# Altium schematic sheet BLDC_Motor_Reversal_Rev2.SchDoc
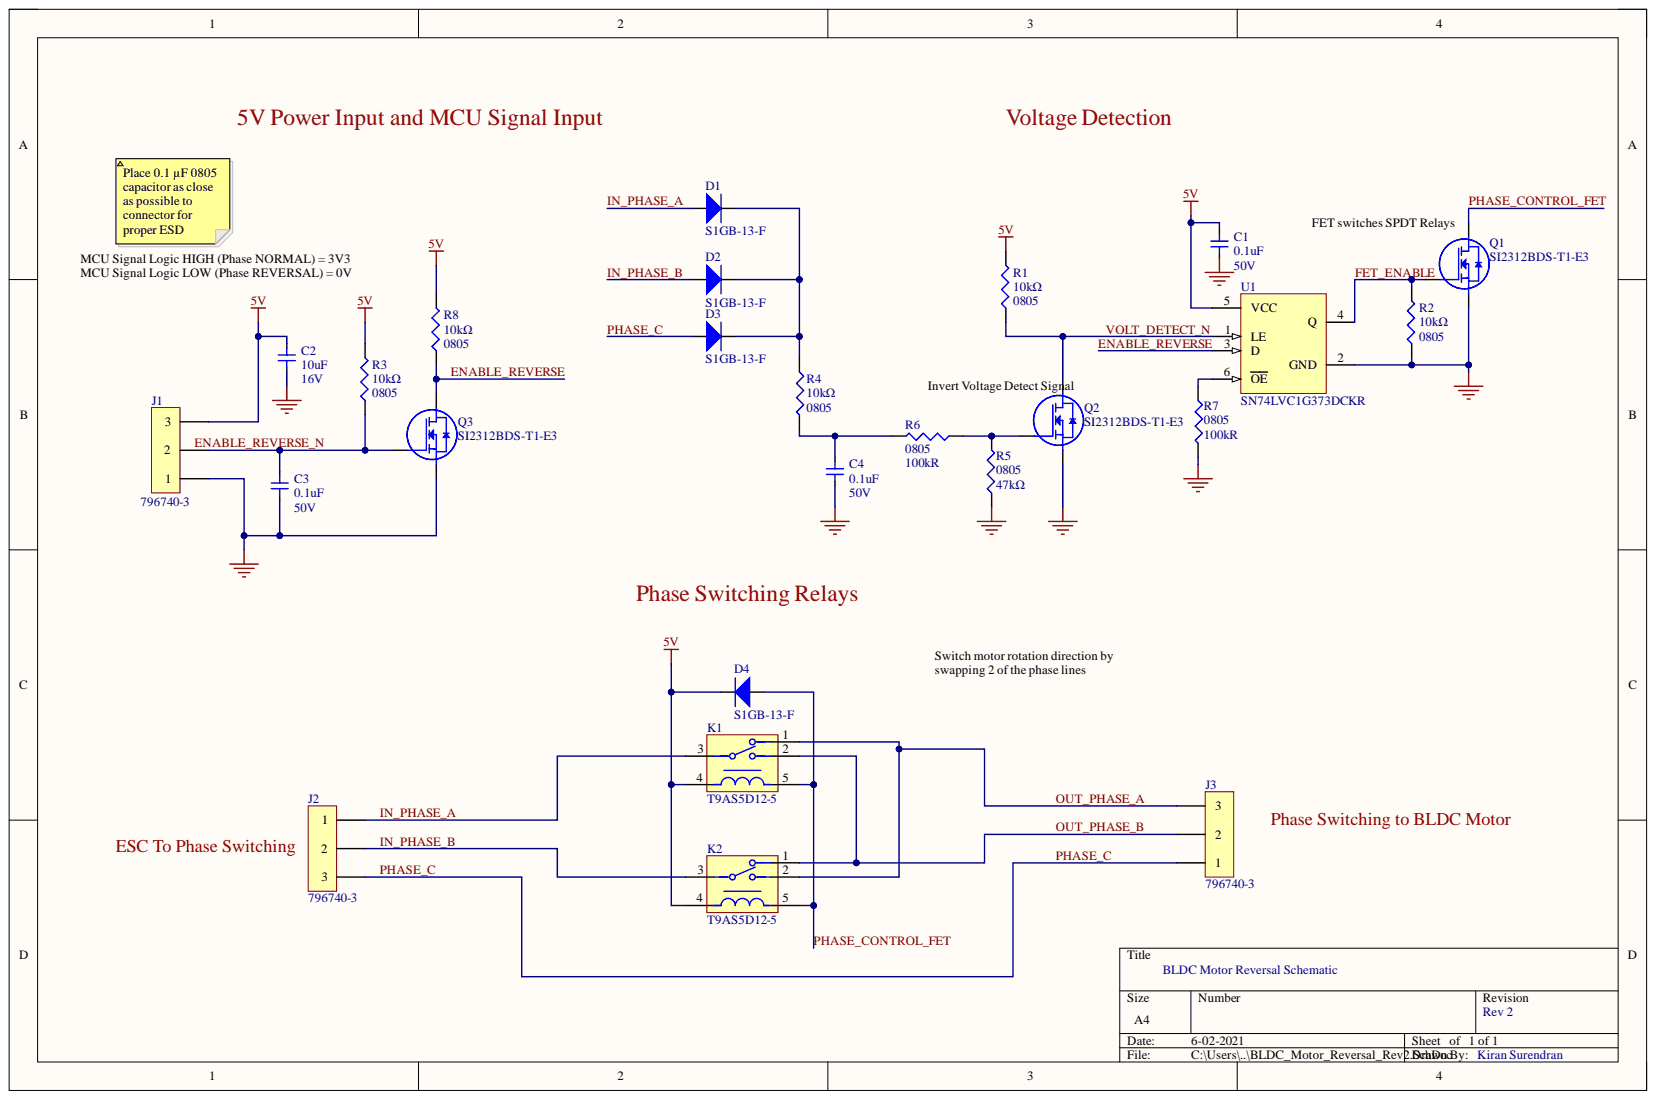
[diagram p0: BLDC_Motor_Reversal_Rev2.SchDoc]

## Parts
C1  Capacitance: 0.1uF  Voltage Rating: 50V  [C0805C104K5RACTU]  (hidden comment: C0805C104K5RACTU)
C2  Capacitance: 10uF  Voltage Rating: 16V  [C0805C106K4PACTU]  (hidden comment: C0805C106K4PACTU)
C3  Capacitance: 0.1uF  Voltage Rating: 50V  [C0805C104K5RACTU]  (hidden comment: C0805C104K5RACTU)
C4  Capacitance: 0.1uF  Voltage Rating: 50V  [C0805C104K5RACTU]  (hidden comment: C0805C104K5RACTU)
D1  S1GB-13-F  [S1GB-13-F]
D2  S1GB-13-F  [S1GB-13-F]
D3  S1GB-13-F  [S1GB-13-F]
D4  S1GB-13-F  [S1GB-13-F]
J1  796740-3  [796740-3]
J2  796740-3  [796740-3]
J3  796740-3  [796740-3]
K1  T9AS5D12-5  [T9AS5D12-5]
K2  T9AS5D12-5  [T9AS5D12-5]
Q1  SI2312BDS-T1-E3  [SI2312BDS-T1-E3]
Q2  SI2312BDS-T1-E3  [SI2312BDS-T1-E3]
Q3  SI2312BDS-T1-E3  [SI2312BDS-T1-E3]
R1  Package: 0805  Resistance: 10kΩ  [RC0805JR-0710KL]  (hidden comment: RC0805JR-0710KL)
R2  Package: 0805  Resistance: 10kΩ  [RC0805JR-0710KL]  (hidden comment: RC0805JR-0710KL)
R3  Package: 0805  Resistance: 10kΩ  [RC0805JR-0710KL]  (hidden comment: RC0805JR-0710KL)
R4  Package: 0805  Resistance: 10kΩ  [RC0805JR-0710KL]  (hidden comment: RC0805JR-0710KL)
R5  Package: 0805  Resistance: 47kΩ  [RC0805JR-0747KL]  (hidden comment: RC0805JR-0747KL)
R6  Case Code (Imperial): 0805  Resistance: 100kR  [RC0805JR-07100KL]  (hidden comment: RC0805JR-07100KL)
R7  Case Code (Imperial): 0805  Resistance: 100kR  [RC0805JR-07100KL]  (hidden comment: RC0805JR-07100KL)
R8  Package: 0805  Resistance: 10kΩ  [RC0805JR-0710KL]  (hidden comment: RC0805JR-0710KL)
U1  SN74LVC1G373DCKR  [DCK0006A_N]

## Nets
5V: C1.2 C2.2 D4.1 J1.3 K1.4 K2.4 R1.2 R3.2 R8.2 U1.5(VCC)
GND: C1.1 C2.1 C3.1 C4.1 J1.1 Q1.2 Q2.2 Q3.2 R2.1 R5.1 R7.2 U1.2(GND)
ENABLE_REVERSE: Q3.3 R8.1 U1.3(D)
FET_ENABLE: Q1.1 R2.2 U1.4(Q)
IN_PHASE_A: D1.1 J2.1 K1.3
IN_PHASE_B: D2.1 J2.2 K2.3
OUT_PHASE_A: J3.3 K1.1 K2.2
OUT_PHASE_B: J3.2 K1.2 K2.1
PHASE_C: D3.1 J2.3 J3.1
PHASE_CONTROL_FET: D4.2 K1.5 K2.5 Q1.3
VOLT_DETECT_N: Q2.3 R1.1 U1.1(LE)
NetC3_2: C3.2 J1.2 Q3.1 R3.1
NetC4_2: C4.2 R4.2 R6.2
NetD1_2: D1.2 D2.2 D3.2 R4.1
NetQ2_1: Q2.1 R5.2 R6.1
NetR7_1: R7.1 U1.6(OE)
ENABLE_REVERSE_N:

## Library data of the parts
C1 C3 C4 = C0805C104K5RACTU: Cap Ceramic 0.1uF 50V X7R 10% SMD 2.0 x 1.2 mm 125 C Paper T/R; Manufacturer 1: KEMET; Case/Package: 0805; Component Kind: Standard; Library Name: BLDC_Motor_SchLib.SchLib; Manufacturer Part Number 1: C0805C104K5RACTU; Supplier 1: Digi-Key; Supplier Part Number 1: 399-1170-1-ND
C2 = C0805C106K4PACTU: CERAMIC CAPACITOR, 10UF, 16V, X5R, 10%, 0805; Manufacturer 1: KEMET; Case/Package: 0805; Component Kind: Standard; Library Name: BLDC_Motor_Lib.SchLib; Manufacturer Part Number 1: C0805C106K4PACTU; Supplier 1: Digi-Key; Supplier Part Number 1: 399-8012-1-ND
D1-D4 = S1GB-13-F: Flyback Diode for SPDT; Manufacturer 1: Diodes Incorporated; IFSM: 8.0A; VRRM: 325V; Component Kind: Standard; Manufacturer Part Number 1: S1GB-13-F; Supplier 1: Digi-Key; Supplier Part Number 1: S1GB-FDICT-ND
  D1-D3: Library Name: BLDC_Motor_Lib.SchLib
  D4: Library Name: BLDC_Motor_SchLib.SchLib
J1-J3 = 796740-3: TERM BLK 3P SIDE ENT 6.35MM PCB; Manufacturer 1: TE Connectivity AMP Connectors; Component Kind: Standard; Library Name: BLDC_Motor_Lib.SchLib; Manufacturer Part Number 1: 796740-3; Supplier 1: Digi-Key; Supplier Part Number 1: A98276-ND
K1 K2 = T9AS5D12-5: RELAY GEN PURPOSE SPDT 20A 5V; Manufacturer 1: TE Connectivity Potter & Brumfield Relays; Coil Current: 200mA; Contact Voltage Rating (AC): 277V; Current Rating: 30A; Coil Voltage (DC): 5V; Component Kind: Standard; Contact Current Rating: 20A; Library Name: BLDC_Motor_Lib.SchLib; Manufacturer Part Number 1: T9AS5D12-5; Supplier 1: Digi-Key; Supplier Part Number 1: T9AS5D12-5-ND; Voltage Rating (DC): 5V
Q1-Q3 = SI2312BDS-T1-E3: MOSFET; Manufacturer 1: Vishay Siliconix; Component Kind: Standard; Manufacturer Part Number 1: SI2312BDS-T1-E3; Supplier 1: Digi-Key; Supplier Part Number 1: SI2312BDS-T1-E3CT-ND
  Q1: Library Name: BLDC_Motor_Lib.SchLib
  Q2 Q3: Library Name: BLDC_Motor_SchLib.SchLib
R1-R4 R8 = RC0805JR-0710KL: Res Thick Film 0805 10K Ohm 5% 1/8W ±100ppm/°C Molded SMD Paper T/R; Manufacturer 1: Yageo; Component Kind: Standard; Library Name: BLDC_Motor_SchLib.SchLib; Manufacturer Part Number 1: RC0805JR-0710KL; Supplier 1: Digi-Key; Supplier Part Number 1: 311-10KARCT-ND; Tolerance: 5%
R5 = RC0805JR-0747KL: 47 kOhms ±5% 0.125W, 1/8W Chip Resistor 0805 (2012 Metric) Moisture Resistant Thick Film; Manufacturer 1: Yageo; Component Kind: Standard; Library Name: BLDC_Motor_SchLib.SchLib; Manufacturer Part Number 1: RC0805JR-0747KL; Supplier 1: Digi-Key; Supplier Part Number 1: 311-47KARCT-ND
R6 R7 = RC0805JR-07100KL: Res Thick Film 0805 100K Ohm 5% 1/8W 100ppm/C Molded SMD SMD Paper T/R; Manufacturer: Yageo; Max Power Dissipation: 125mW; Temperature Coefficient: 100ppm/°C; Voltage Rating: 150V; Case Code (Metric): 2012; Case/Package: 0805; Category: Chip SMD Resistors; Component Kind: Standard; ComponentLink1Description: https://datasheet.ciiva.com/7941/442941-7941865.pdf?src-supplier=Element14; ComponentLink1URL: https://datasheet.ciiva.com/7941/442941-7941865.pdf?src-supplier=Element14; ComponentLink2Description: https://datasheet.ciiva.com/7941/442941-7941864.pdf?src-supplier=Element14; ComponentLink2URL: https://datasheet.ciiva.com/7941/442941-7941864.pdf?src-supplier=Element14; ComponentLink3Description: https://datasheet.ciiva.com/15190/getdatasheetpartid-479267-15190029.pdf?src-supplier=Verical; ComponentLink3URL: https://datasheet.ciiva.com/15190/getdatasheetpartid-479267-15190029.pdf?src-supplier=Verical; Construction: Rectangular; Contact Plating: Tin,Matte,Lead; Depth: 1.25mm; Features: Moisture Resistant; Lead Free: Lead Free; Length: 2mm; Library Name: BLDC_Motor_SchLib.SchLib; Manufacturer Part Number: RC0805JR-07100KL; Max Operating Temperature: 155°C; Military Standard: Not; Min Operating Temperature: -55°C; Number of Terminations: 2; Packaging: Tape and Reel; Power Rating: 125mW; Pricing 1: 1=0.10000, 10=0.03600, 100=0.01450, 1000=0.00652, 2500=0.00566 (USD); Radiation Hardening: No; REACH SVHC: Unknown; Resistance Tolerance: 5%; Resistor Type: Thick Film; RoHS: Yes; RoHS Compliant: Yes; Series: RC; Size Code: 0805; Stock 1: 292552; Supplier 1: Digi-Key; Supplier Part Number 1: 311-100KARCT-ND; Termination: SMD/SMT; Tolerance: 5%
U1 = SN74LVC1G373DCKR: IC LTCH D-TYP SGL 3-OUT SC70-6; Manufacturer: Texas Instruments; High Level Output Current: -32mA; Independent Circuits: 1; Logic Function: D-Type,Latch; Low Level Output Current: 32mA; Max Supply Voltage: 5.5V; Min Supply Voltage: 1.65V; Operating Supply Voltage: 3.3V; Output Type: 3-STATE; Polarity: Non-Inverting; Propagation Delay: 4ns; Quiescent Current: 10uA; Turn-On Delay Time: 1ns; Case/Package: SC; Category: Logic Gates; Component Kind: Standard; ComponentLink1Description: https://datasheet.ciiva.com/14288/sn74lvc1g373-14288210.pdf?src-supplier=Avnet; ComponentLink1URL: https://datasheet.ciiva.com/14288/sn74lvc1g373-14288210.pdf?src-supplier=Avnet; ComponentLink2Description: https://datasheet.ciiva.com/15088/getdatasheetpartid-416701-15088509.pdf?src-supplier=Verical; ComponentLink2URL: https://datasheet.ciiva.com/15088/getdatasheetpartid-416701-15088509.pdf?src-supplier=Verical; ComponentLink3Description: https://datasheet.ciiva.com/23782/1634225-23782997.pdf?src-supplier=Newark; ComponentLink3URL: https://datasheet.ciiva.com/23782/1634225-23782997.pdf?src-supplier=Newark; ComponentLink4Description: https://datasheet.ciiva.com/1881/sn74lvc1g373-1881421.pdf?src-supplier=Digi-Key; ComponentLink4URL: https://datasheet.ciiva.com/1881/sn74lvc1g373-1881421.pdf?src-supplier=Digi-Key; ComponentLink5Description: https://datasheet.ciiva.com/1881/sn74lvc1g373-1881422.pdf?src-supplier=Digi-Key; ComponentLink5URL: https://datasheet.ciiva.com/1881/sn74lvc1g373-1881422.pdf?src-supplier=Digi-Key; ComponentLink6Description: https://datasheet.ciiva.com/1881/sn74lvc1g373-1881423.pdf?src-supplier=Digi-Key; ComponentLink6URL: https://datasheet.ciiva.com/1881/sn74lvc1g373-1881423.pdf?src-supplier=Digi-Key; ComponentLink7Description: https://datasheet.ciiva.com/14288/sn74lvc1g373-14288214.pdf?src-supplier=Avnet; ComponentLink7URL: https://datasheet.ciiva.com/14288/sn74lvc1g373-14288214.pdf?src-supplier=Avnet; ComponentLink8Description: https://datasheet.ciiva.com/1508/1836498-1508503.pdf?src-supplier=Element14; ComponentLink8URL: https://datasheet.ciiva.com/1508/1836498-1508503.pdf?src-supplier=Element14; ComponentLink9Description: https://datasheet.ciiva.com/1508/1836498-1508504.pdf?src-supplier=Element14; ComponentLink9URL: https://datasheet.ciiva.com/1508/1836498-1508504.pdf?src-supplier=Element14; Contact Plating: Gold; Height: 1mm; Lead Free: Lead Free; Length: 2.15mm; Library Name: BLDC_Motor_SchLib.SchLib; Manufacturer Part Number: SN74LVC1G373DCKR; Max Operating Temperature: 125°C; Min Operating Temperature: -40°C; Mount: Surface Mount; Number of Bits: 1; Number of Channels: 1; Number of Circuits: 1; Number of Elements: 1; Number of Gates: 1; Number of Input Lines: 1; Number of Output Lines: 1; Number of Pins: 6; Output Current: 32mA; Package Quantity: 3000; Packaging: Tape and Reel; Pricing 1: 1=0.40000, 10=0.32400, 25=0.29640, 100=0.22050, 250=0.19980, 500=0.16536, 1000=0.12402 (USD); Radiation Hardening: No; REACH SVHC: No SVHC; RoHS: Yes; Series: 74LVC; Stock 1: 1019; Supplier 1: Digi-Key; Supplier Part Number 1: 296-18775-1-ND; Technology: CMOS; Termination: SMD/SMT; Weight: 8.8E-05oz; Width: 1.4mm
